# Altium schematic sheet SEPL-315Rev1.00.SchDoc
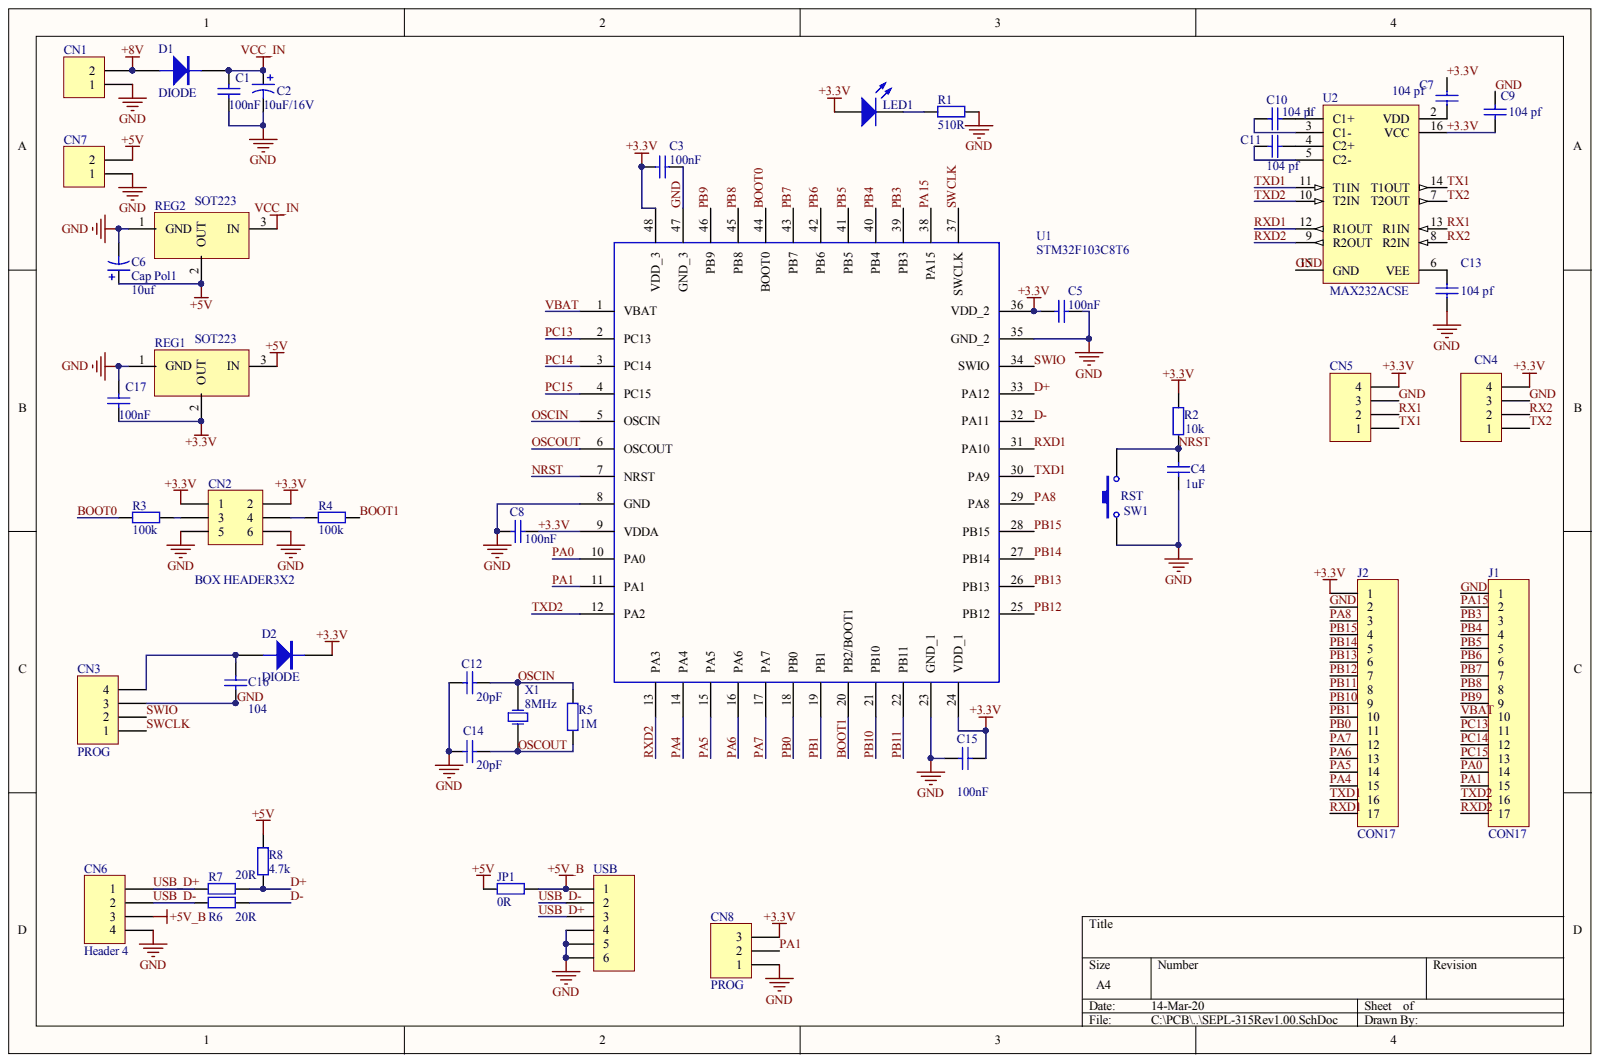
[diagram p0: SEPL-315Rev1.00.SchDoc]

## Parts
C1  value 100nF  [RES 0805/2012]
C2  value 10uF/16V  [EL1-5/2.5GDR]  (hidden comment: EL10uF/16V)
C3  value 100nF  [RES 0805/2012]
C4  value 1uF  [RES 0805/2012]
C5  value 100nF  [RES 0805/2012]
C6  Cap Pol1  value 10uf  [EL1-5/2.5GDR]
C7  104 pf  [RES 0805/2012]
C8  value 100nF  [RES 0805/2012]
C9  104 pf  [RES 0805/2012]
C10  104 pf  [RES 0805/2012]
C11  104 pf  [RES 0805/2012]
C12  value 20pF  [RES 0805/2012]
C13  104 pf  [RES 0805/2012]
C14  value 20pF  [RES 0805/2012]
C15  value 100nF  [RES 0805/2012]
C16  value 104  [RES 0805/2012]
C17  value 100nF  [RES 0805/2012]
CN1  (no value)  [SIP2/B]
CN2  BOX HEADER3X2  [HDR2X3]
CN3  PROG  [SIP4]
CN4  Header 4  [SIP4]  (value not drawn)
CN5  (no value)  [SIP4]
CN6  Header 4  [SIP4/B]
CN7  (no value)  [SIP2/B]
CN8  PROG  [HDR1X3]
D1  DIODE  [DO214AC SMA]
D2  DIODE  [DO214AC SMA]
J1  CON17  [HDR1X17]
J2  CON17  [HDR1X17]
JP1  value 0R  [RES 0805/2012]
LED1  LED0  [LED]  (value not drawn)
R1  value 510R  [RES 0805/2012]  (hidden comment: Res2)
R2  value 10k  [RES 0805/2012]  (hidden comment: Res2)
R3  value 100k  [RES 0805/2012]  (hidden comment: Res2)
R4  value 100k  [RES 0805/2012]  (hidden comment: Res2)
R5  value 1M  [RES 0805/2012]  (hidden comment: Res2)
R6  value 20R  [RES 0805/2012]  (hidden comment: Res2)
R7  value 20R  [RES 0805/2012]  (hidden comment: Res2)
R8  value 4.7k  [RES 0805/2012]  (hidden comment: Res2)
REG1  SOT223  [SOT-223A]
REG2  SOT223  [SOT-223A]
SW1  RST  [SW_TACTILE_SMD]
U1  STM32F103C8T6  [STM32/LQFP48/7X7MM]
U2  MAX232ACSE  [MAX3232CSE]
USB  Header 6  [CN]  (value not drawn)
X1  8MHz  [CSTL]

## Nets
+5V: C6.1 CN7.2 JP1.2 R8.2 REG1.3 REG2.2
+5V_B: CN6.3 JP1.1 USB.1
+8V: CN1.2 D1.2
VCC_IN: C1.2 C2.1 D1.1 REG2.3
+3.3V: C3.1 C5.1 C7.1 C8.2 C9.1 C15.1 C17.1 CN2.1 CN2.2 CN4.4 CN5.4 CN8.3 D2.1 J2.1 LED1.1 R2.2 REG1.2 U1.9(VDDA) U1.24(VDD_1) U1.36(VDD_2) U1.48(VDD_3) U2.16(VCC)
BOOT0: R3.1 U1.44(BOOT0)
BOOT1: R4.1 U1.20(PB2/BOOT1)
D+: R7.1 R8.1 U1.33(PA12)
D-: R6.1 U1.32(PA11)
GND: C1.1 C2.2 C3.2 C4.2 C5.2 C6.2 C8.1 C9.2 C12.1 C13.1 C14.1 C15.2 C16.1 C17.2 CN1.1 CN2.5 CN2.6 CN3.3 CN4.3 CN5.3 CN6.4 CN7.1 CN8.1 J1.1 J2.2 R1.1 REG1.1 REG2.1 SW1.1 U1.8(GND) U1.23(GND_1) U1.35(GND_2) U1.47(GND_3) U2.15(GND) USB.4 USB.5 USB.6
NRST: C4.1 R2.1 SW1.2 U1.7(NRST)
OSCIN: C12.2 R5.2 U1.5(OSCIN) X1.2
OSCOUT: C14.2 R5.1 U1.6(OSCOUT) X1.1
PA0: J1.14 U1.10(PA0)
PA1: CN8.2 J1.15 U1.11(PA1)
PA4: J2.15 U1.14(PA4)
PA5: J2.14 U1.15(PA5)
PA6: J2.13 U1.16(PA6)
PA7: J2.12 U1.17(PA7)
PA8: J2.3 U1.29(PA8)
PA15: J1.2 U1.38(PA15)
PB0: J2.11 U1.18(PB0)
PB1: J2.10 U1.19(PB1)
PB3: J1.3 U1.39(PB3)
PB4: J1.4 U1.40(PB4)
PB5: J1.5 U1.41(PB5)
PB6: J1.6 U1.42(PB6)
PB7: J1.7 U1.43(PB7)
PB8: J1.8 U1.45(PB8)
PB9: J1.9 U1.46(PB9)
PB10: J2.9 U1.21(PB10)
PB11: J2.8 U1.22(PB11)
PB12: J2.7 U1.25(PB12)
PB13: J2.6 U1.26(PB13)
PB14: J2.5 U1.27(PB14)
PB15: J2.4 U1.28(PB15)
PC13: J1.11 U1.2(PC13)
PC14: J1.12 U1.3(PC14)
PC15: J1.13 U1.4(PC15)
RX1: CN5.2 U2.13(R1IN)
RX2: CN4.2 U2.8(R2IN)
RXD1: J2.17 U1.31(PA10) U2.12(R1OUT)
RXD2: J1.17 U1.13(PA3) U2.9(R2OUT)
SWCLK: CN3.1 U1.37(SWCLK)
SWIO: CN3.2 U1.34(SWIO)
TX1: CN5.1 U2.14(T1OUT)
TX2: CN4.1 U2.7(T2OUT)
TXD1: J2.16 U1.30(PA9) U2.11(T1IN)
TXD2: J1.16 U1.12(PA2) U2.10(T2IN)
USB_D+: CN6.1 R7.2 USB.3
USB_D-: CN6.2 R6.2 USB.2
VBAT: J1.10 U1.1(VBAT)
NetC7_2: C7.2 U2.2(VDD)
NetC10_1: C10.1 U2.1(C1+)
NetC10_2: C10.2 U2.3(C1-)
NetC11_1: C11.1 U2.4(C2+)
NetC11_2: C11.2 U2.5(C2-)
NetC13_2: C13.2 U2.6(VEE)
NetC16_2: C16.2 CN3.4 D2.2
NetCN2_3: CN2.3 R3.2
NetCN2_4: CN2.4 R4.2
NetLED1_2: LED1.2 R1.2

## Library data of the parts
C1 C3-C5 C8 C12 C14-C17 = Cap: Capacitor; Component Kind: Standard; LatestRevisionDate: 17-Jul-2002; LatestRevisionNote: Re-released for DXP Platform.; PackageReference: RAD-0.3; Published: 8-Jun-2000; Signal Integrity: Cap; Simulation: CAP; Publisher: Altium Limited
  C1 C17: Library Name: mother board.SCHLIB
  C3-C5 C8 C12 C14-C16: Library Name: Miscellaneous Devices.IntLib
C2 = Cap Pol3: Polarized Capacitor (Surface Mount); Component Kind: Standard; LatestRevisionDate: 29-May-2009; LatestRevisionNote: IPC-7351 Footprint Added.; Library Name: Miscellaneous Devices.IntLib; PackageDescription: Chip Capacitor; PackageReference: C0805; Published: 8-Jun-2000; Signal Integrity: Cap Pol; Simulation: CAP; Publisher: Altium Limited
C6 = Cap Pol1: Polarized Capacitor (Radial); Component Kind: Standard; LatestRevisionDate: 17-Jul-2002; LatestRevisionNote: Re-released for DXP Platform.; Library Name: Miscellaneous Devices.IntLib; PackageReference: RB7.6-15; Published: 8-Jun-2000; Signal Integrity: Cap Pol; Simulation: CAP; Publisher: Altium Limited
C7 C9-C11 C13 = Cap: Capacitor; Value: 100pF; Component Kind: Standard; LatestRevisionDate: 17-Jul-2002; LatestRevisionNote: Re-released for DXP Platform.; PackageReference: RAD-0.3; Published: 8-Jun-2000; Signal Integrity: Cap; Simulation: CAP; Publisher: Altium Limited
  C7 C10 C11 C13: Library Name: Miscellaneous Devices.IntLib
  C9: Library Name: mother board.SCHLIB
CN1 CN7 = Header 2: Header, 2-Pin; Value: BERG2; Component Kind: Standard; LatestRevisionDate: 17-Jul-2002; LatestRevisionNote: Re-released for DXP Platform.; Library Name: Miscellaneous Connectors.IntLib; Signal Integrity: Connector; Publisher: Altium Limited
CN2 = Header 3X2: Header, 3-Pin, Dual row; Component Kind: Standard; LatestRevisionDate: 17-Jul-2002; LatestRevisionNote: Re-released for DXP Platform.; Library Name: Miscellaneous Connectors.IntLib; Signal Integrity: Connector; Publisher: Altium Limited
CN3-CN6 = Header 4: Header, 4-Pin; Component Kind: Standard; LatestRevisionDate: 17-Jul-2002; LatestRevisionNote: Re-released for DXP Platform.; Library Name: Miscellaneous Connectors.IntLib; Signal Integrity: Connector; Publisher: Altium Limited
CN8 = Header 3: Header, 3-Pin; Component Kind: Standard; LatestRevisionDate: 17-Jul-2002; LatestRevisionNote: Re-released for DXP Platform.; Library Name: Miscellaneous Connectors.IntLib; Signal Integrity: Connector; Publisher: Altium Limited
J1 J2 = CON17: Connector; Component Kind: Standard; Library Name: Miscellaneous Devices.lib
JP1 R1-R8 = Res2: Resistor; Component Kind: Standard; LatestRevisionDate: 17-Jul-2002; LatestRevisionNote: Re-released for DXP Platform.; Library Name: Miscellaneous Devices.IntLib; PackageDescription: Axial Device, Thru-Hole; 2 Leads; 0.4 in Pin Spacing; PackageReference: AXIAL-0.4; Published: 8-Jun-2000; Signal Integrity: Res; Simulation: RESISTOR; Publisher: Altium Limited
LED1 = LED0: Typical INFRARED GaAs LED; Component Kind: Standard; LatestRevisionDate: 08-Jul-2005; LatestRevisionNote: Mech Layer 1 removed.; Library Name: Miscellaneous Devices.IntLib; PackageReference: LED-0; Published: 8-Jun-2000; Simulation: LED0; Publisher: Altium Limited
REG1 REG2 = SOT223: REGULETOR; Component Kind: Standard; Library Name: mother board.SCHLIB
SW1 = SW-PB: Switch; Component Kind: Standard; LatestRevisionDate: 17-Jul-2002; LatestRevisionNote: Re-released for DXP Platform.; Library Name: Miscellaneous Devices.IntLib; PackageDescription: Switch; 2 Leads; PackageReference: SPST-2; Published: 24-Mar-1999; Publisher: Altium Limited
U2 = MAX232ACSE: +5V Powered, Multi-Channel RS-232 Driver/Receiver; Code_IPC: SOIC127P600-16; Code_JEDEC: MS-012-AC; Component Kind: Standard; ComponentLink1Description: Manufacturer Link; ComponentLink1URL: http://www.maxim-ic.com/; ComponentLink2Description: Datasheet; ComponentLink2URL: http://pdfserv.maxim-ic.com/en/ds/MAX220-MAX249.pdf; LatestRevisionDate: 13-Apr-2006; LatestRevisionNote: IPC-7351 Footprint Added.; Library Name: Maxim Communication Transceiver.IntLib; PackageDescription: 16-Pin Small Outline Integrated Circuit 1.27 mm Pitch; PackageReference: NSO16; PCB3D: NSO16; Published: 16-Jan-2001; Publisher: Altium Limited
USB = Header 6: Header, 6-Pin; Component Kind: Standard; LatestRevisionDate: 17-Jul-2002; LatestRevisionNote: Re-released for DXP Platform.; Library Name: Miscellaneous Connectors.IntLib; Signal Integrity: Connector; Publisher: Altium Limited
X1 = XTAL: Crystal Oscillator; Component Kind: Standard; LatestRevisionDate: 17-Jul-2002; LatestRevisionNote: Re-released for DXP Platform.; Library Name: Miscellaneous Devices.IntLib; PackageReference: R38; Published: 8-Jun-2000; Simulation: XTAL; Publisher: Altium Limited
D1 D2 = DIODE: Component Kind: Standard; Description: Diode; Library Name: Miscellaneous Devices.lib
U1 = STM32F103C8T6: Component Kind: Standard; Library Name: mother board.SCHLIB
